# Altium schematic sheet EMatches.SchDoc
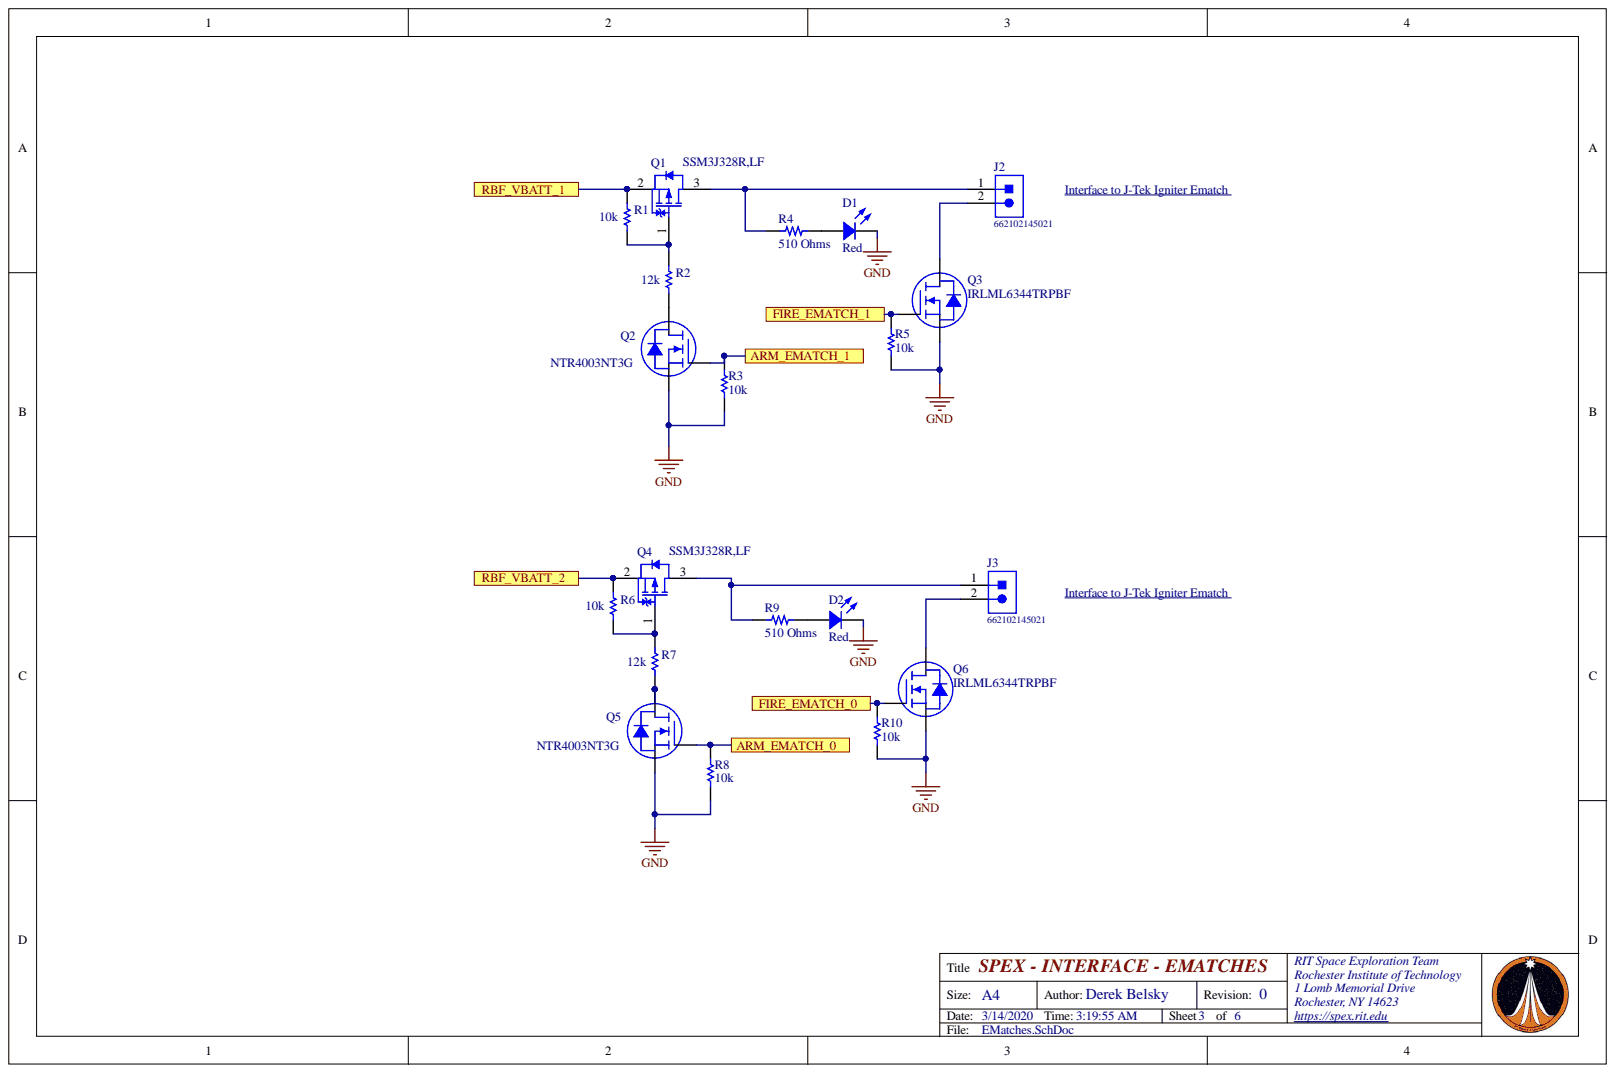
[diagram p0: EMatches.SchDoc]

## Parts
D1  Red  [LED 1206_3216 RED]
D2  Red  [LED 1206_3216 RED]
J2  662102145021  [662102145021]
J3  662102145021  [662102145021]
Q1  SSM3J328R,LF  [TOSH-SOT-23F_V]
Q2  NTR4003NT3G  [ON SEMI SOT-23-3]
Q3  IRLML6344TRPBF  [INFINEON SOT-23-3]
Q4  SSM3J328R,LF  [TOSH-SOT-23F_V]
Q5  NTR4003NT3G  [ON SEMI SOT-23-3]
Q6  IRLML6344TRPBF  [INFINEON SOT-23-3]
R1  value 10k  [RESC1609X50X30NL8T20]  (hidden comment: CRCW060310K0FKEA)
R2  value 12k  [RESC1609X50X30LL10T20]  (hidden comment: CRCW060312K0FKEA)
R3  value 10k  [RESC1609X50X30NL8T20]  (hidden comment: CRCW060310K0FKEA)
R4  510 Ohms  [RES 0603_1608]
R5  value 10k  [RESC1609X50X30NL8T20]  (hidden comment: CRCW060310K0FKEA)
R6  value 10k  [RESC1609X50X30NL8T20]  (hidden comment: CRCW060310K0FKEA)
R7  value 12k  [RESC1609X50X30LL10T20]  (hidden comment: CRCW060312K0FKEA)
R8  value 10k  [RESC1609X50X30NL8T20]  (hidden comment: CRCW060310K0FKEA)
R9  510 Ohms  [RES 0603_1608]
R10  value 10k  [RESC1609X50X30NL8T20]  (hidden comment: CRCW060310K0FKEA)

## Ports
ARM_EMATCH_0, ARM_EMATCH_1, FIRE_EMATCH_0, FIRE_EMATCH_1, RBF_VBATT_1, RBF_VBATT_2

## Nets
GND: D1.2 D2.2 Q2.2 Q3.2 Q5.2 Q6.2 R3.2 R5.2 R8.2 R10.2
ARM_EMATCH_0: Q5.1 R8.1 ; port ARM_EMATCH_0
ARM_EMATCH_1: Q2.1 R3.1 ; port ARM_EMATCH_1
FIRE_EMATCH_0: Q6.1 R10.1 ; port FIRE_EMATCH_0
FIRE_EMATCH_1: Q3.1 R5.1 ; port FIRE_EMATCH_1
RBF_VBATT_1: Q1.2 R1.2 ; port RBF_VBATT_1
RBF_VBATT_2: Q4.2 R6.2 ; port RBF_VBATT_2
NetD1_1: D1.1 R4.2
NetD2_1: D2.1 R9.2
NetJ2_1: J2.1 Q1.3 R4.1
NetJ2_2: J2.2 Q3.3
NetJ3_1: J3.1 Q4.3 R9.1
NetJ3_2: J3.2 Q6.3
NetQ1_1: Q1.1 R1.1 R2.2
NetQ2_3: Q2.3 R2.1
NetQ4_1: Q4.1 R6.1 R7.2
NetQ5_3: Q5.3 R7.1

## Library data of the parts
D1 D2 = HSMC-C350: LED RED CHIP SMD; Manufacturer: Broadcom Limited; Configuration: Standard; Current - Test: 20mA; Database Table Name: LED - Indication; Lens Color: 1.20mm; Lens Size: 1.10mm Dia; Lens Style: Round with Domed Top; Viewing Angle: 70°; Voltage - Forward (Vf) (Typ): 2V; Wavelength - Dominant: 630nm; Wavelength - Peak: 643nm; Color: Red; Component Kind: Standard; ComponentLink1Description: Datasheet; ComponentLink1URL: https://docs.broadcom.com/docs/HSMC-C350-DS100; ComponentLink2Description: DigiKey Link; ComponentLink2URL: http://digikey.com/product-detail/en/broadcom-limited/HSMC-C350/516-4369-1-ND/10239796; Device Package: 1206 (3216 Metric); Height (Max): 1.20mm; LastUpdated: 1/21/2020 3:14:28 AM; Library Name: Celestial Altium Library - altium_library.DbLib; Lifecycle Status: Active; Minimum Order: 1; Mounting Type: Surface Mount; Package / Case: 1206 (3216 Metric); Packaging: TapeAndReel; PartId: 195; Part Number: HSMC-C350; Part Status: Active; Price: 0.21; Series: -; Size / Dimension: 3.20mm L x 1.60mm W; Supplier 1: DigiKey; Supplier Device Package: Chip LED; Supplier Part Number 1: 516-4369-1-ND; Value: Red
J2 J3 = CMP-1502-00482-1: SMT Male Header WR-MPC3, with SMT Solder Tabs, Right Angled, Single Row, pitch 3 mm, 2 pins; Manufacturer: Wurth Elektronik; Contact Resistance: 10mOhm; Pin Number: 2; Pitch: 3mm; Working Voltage: 250VAC; Component Kind: Standard; Datasheet URL: https://katalog.we-online.de/em/datasheet/6621xx145021.pdf; Gender: Male; Library Name: Altium Content Vault; Manufacturer URL: http://www.we-online.de; Mounting Technology: SMT; Operating Temperature: -40to105degC; Orientation: RightAngled; Package Reference: 662102145021; Packaging: TapeandReel; Part Number: 662102145021; Publisher: WurthElektronik
Q1 Q4 = CMP-2100-03686-1: TOSHIBA Field-Effect Transistor Silicon P-Channel MOS Type (U-MOS VI), 20 V, -55 to 125 degC, 3-Pin SOTFL, RoHS, Tape and Reel; Continuous Drain Current (ID): 6A; Drain to Source Breakdown Voltage: -20V; Drain to Source Resistance: 47.4mOhm; Drain to Source Voltage (Vdss): 20V; Element Configuration: Single; Gate to Source Voltage (Vgs): 8V; Input Capacitance: 840pF; Max Power Dissipation: 1W; Number of Channels: 1; Power Dissipation: 2W; Rds On Max: 29.8mOhm; Turn-Off Delay Time: 107ns; Turn-On Delay Time: 32ns; Case/Package: SOT-23-3; Component Kind: Standard; Datasheet URL: https://toshiba.semicon-storage.com/info/docget.jsp?did=2429&prodName=SSM3J328R; Datasheet Version: 41699.0; Library Name: Altium Content Vault; Manufacturer: Toshiba; Manufacturer URL: http://www.toshiba.com/; Max Operating Temperature: 150degC; Min Operating Temperature: -55degC; Mount: SurfaceMount; Number of Elements: 1; Number of Pins: 3; Package Description: 3-Pin Small Outline Transistor, Flat Lead, Body 2.9 x 1.8 mm, Pitch 0.95 mm; Package Quantity: 3000; Package Reference: SOT-23F; Package URL: https://toshiba.semicon-storage.com/ap-en/design-support/package/detail.SOT-23F.html; Packaging: TapeandReel; Radiation Hardening: No; RoHSCompliant: true
Q2 Q5 = NTR4003NT3G: MOSFET N-CH 30V 500MA SOT-23; Manufacturer: ON Semiconductor; Current - Continuous Drain (Id) @ 25°C: 500mA (Ta); Database Table Name: MOSFET - Single - N-Ch; Drive Voltage (Max Rds On,  Min Rds On): 2.5V, 4V; FET Type: N-Channel; Gate Charge (Qg) (Max) @ Vgs: 1.15nC @ 5V; Input Capacitance (Ciss) (Max) @ Vds: 21pF @ 5V; Power Dissipation (Max): 690mW (Ta); Rds On (Max) @ Id, Vgs: 1.5Ohm @ 10mA, 4V; Vgs (Max): ±20V; Vgs(th) (Max) @ Id: 1.4V @ 250µA; Component Kind: Standard; ComponentLink1Description: Datasheet; ComponentLink1URL: http://www.onsemi.com/pub/Collateral/NTR4003N-D.PDF; ComponentLink2Description: DigiKey Link; ComponentLink2URL: http://digikey.com/product-detail/en/on-semiconductor/NTR4003NT3G/NTR4003NT3GOSCT-ND/1967271; Device Package: TO-236-3, SC-59, SOT-23-3; Drain to Source Voltage (Vdss): 30V; LastUpdated: 1/21/2020 4:01:12 AM; Library Name: Celestial Altium Library - altium_library.DbLib; Lifecycle Status: Active; Minimum Order: 1; Mounting Type: Surface Mount; Operating Temperature: -55°C ~ 150°C (TJ); Package / Case: TO-236-3, SC-59, SOT-23-3; Packaging: TapeAndReel; Part Number: NTR4003NT3G; Part Status: Active; Price: 0.04; Series: -; Supplier 1: DigiKey; Supplier Device Package: SOT-23-3 (TO-236); Supplier Part Number 1: NTR4003NT3GOSCT-ND; Technology: MOSFET (Metal Oxide)
Q3 Q6 = IRLML6344TRPBF: MOSFET N-CH 30V 5A SOT23; Manufacturer: Infineon Technologies; Current - Continuous Drain (Id) @ 25°C: 5A (Ta); Database Table Name: MOSFET - Single - N-Ch; Drive Voltage (Max Rds On,  Min Rds On): 2.5V, 4.5V; FET Type: N-Channel; Gate Charge (Qg) (Max) @ Vgs: 6.8nC @ 4.5V; Input Capacitance (Ciss) (Max) @ Vds: 650pF @ 25V; Power Dissipation (Max): 1.3W (Ta); Rds On (Max) @ Id, Vgs: 29mOhm @ 5A, 4.5V; Vgs (Max): ±12V; Vgs(th) (Max) @ Id: 1.1V @ 10µA; Component Kind: Standard; ComponentLink1Description: Datasheet; ComponentLink1URL: https://www.infineon.com/dgdl/irlml6344pbf.pdf?fileId=5546d462533600a4015356689c44262c; ComponentLink2Description: DigiKey Link; ComponentLink2URL: http://digikey.com/product-detail/en/infineon-technologies/IRLML6344TRPBF/IRLML6344TRPBFCT-ND/2538168; Device Package: TO-236-3, SC-59, SOT-23-3; Drain to Source Voltage (Vdss): 30V; LastUpdated: 1/21/2020 4:00:48 AM; Library Name: Celestial Altium Library - altium_library.DbLib; Lifecycle Status: Active; Minimum Order: 1; Mounting Type: Surface Mount; Operating Temperature: -55°C ~ 150°C (TJ); Package / Case: TO-236-3, SC-59, SOT-23-3; Packaging: TapeAndReel; Part Number: IRLML6344TRPBF; Part Status: Active; Price: 0.12; Series: HEXFET®; Supplier 1: DigiKey; Supplier Device Package: Micro3™/SOT-23; Supplier Part Number 1: IRLML6344TRPBFCT-ND; Technology: MOSFET (Metal Oxide)
R1 R3 R5 R6 R8 R10 = CMP-1666-00005-4: Chip Resistor, 10 KOhm, +/- 1%, 100 mW, -55 to 155 degC, 0603 (1608 Metric), RoHS, Tape and Reel; Manufacturer: Vishay Semiconductor; Voltage Rating: 75 V; Component Kind: Standard; ComponentLink1Description: Manufacturer URL; ComponentLink1URL: http://www.vishay.com/; ComponentLink2Description: Datasheet; ComponentLink2URL: https://datasheet.ciiva.com/11809/0900766b80d98afc-11809037.pdf; DatasheetVersion: 06/2012; Height: 0.45 mm; Length: 1.55 mm; Library Name: Altium Content Vault; Max Operating Temperature: 155 degC; Min Operating Temperature: -55 degC; Mounting Technology: Surface Mount; PackageDescription: 2-Pin Surface Mount Device, Body 1.575 x 0.85 mm; Package Quantity: 5000; PackageReference: 0603; Packaging: Tape and Reel; REACH SVHC: Unknown; RoHSCompliant: true
R2 R7 = CMP-2000-03877-1: Manufacturer: Vishay Dale; Component Kind: Standard; Library Name: Altium Content Vault; Part Number: CRCW060312K0FKEA
R4 R9 = CRCW0603510RFKEAHP: RES SMD 510 OHM 1% 1/4W 0603; Manufacturer: Vishay Dale; Database Table Name: Resistors - Surface Mount - 0603; Power (Watts): 0.25W, 1/4W; Temperature Coefficient: ±100ppm/°C; Component Kind: Standard; ComponentLink1Description: Datasheet; ComponentLink1URL: http://www.vishay.com/docs/20043/crcwhpe3.pdf; ComponentLink2Description: DigiKey Link; ComponentLink2URL: http://digikey.com/product-detail/en/vishay-dale/CRCW0603510RFKEAHP/541-510SCT-ND/5326852; Composition: Thick Film; Device Package: 0603 (1608 Metric); Features: Automotive AEC-Q200, Pulse Withstanding; Height - Seated (Max): 0.022" (0.55mm); LastUpdated: 1/21/2020 12:20:58 AM; Library Name: Celestial Altium Library - altium_library.DbLib; Lifecycle Status: Active; Minimum Order: 1; Number of Terminations: 2; Operating Temperature: -55°C ~ 155°C; Package / Case: 0603 (1608 Metric); Packaging: TapeAndReel; PartId: 14772; Part Number: CRCW0603510RFKEAHP; Part Status: Active; Price: 0.02; Ratings: AEC-Q200; Resistance: 510 Ohms; Series: CRCW-HP; Size / Dimension: 0.063" L x 0.033" W (1.60mm x 0.85mm); Supplier 1: DigiKey; Supplier Device Package: 0603; Supplier Part Number 1: 541-510SCT-ND; Tolerance: ±1%; Value: 510 Ohms
